# Altium schematic sheet Audio.SchDoc
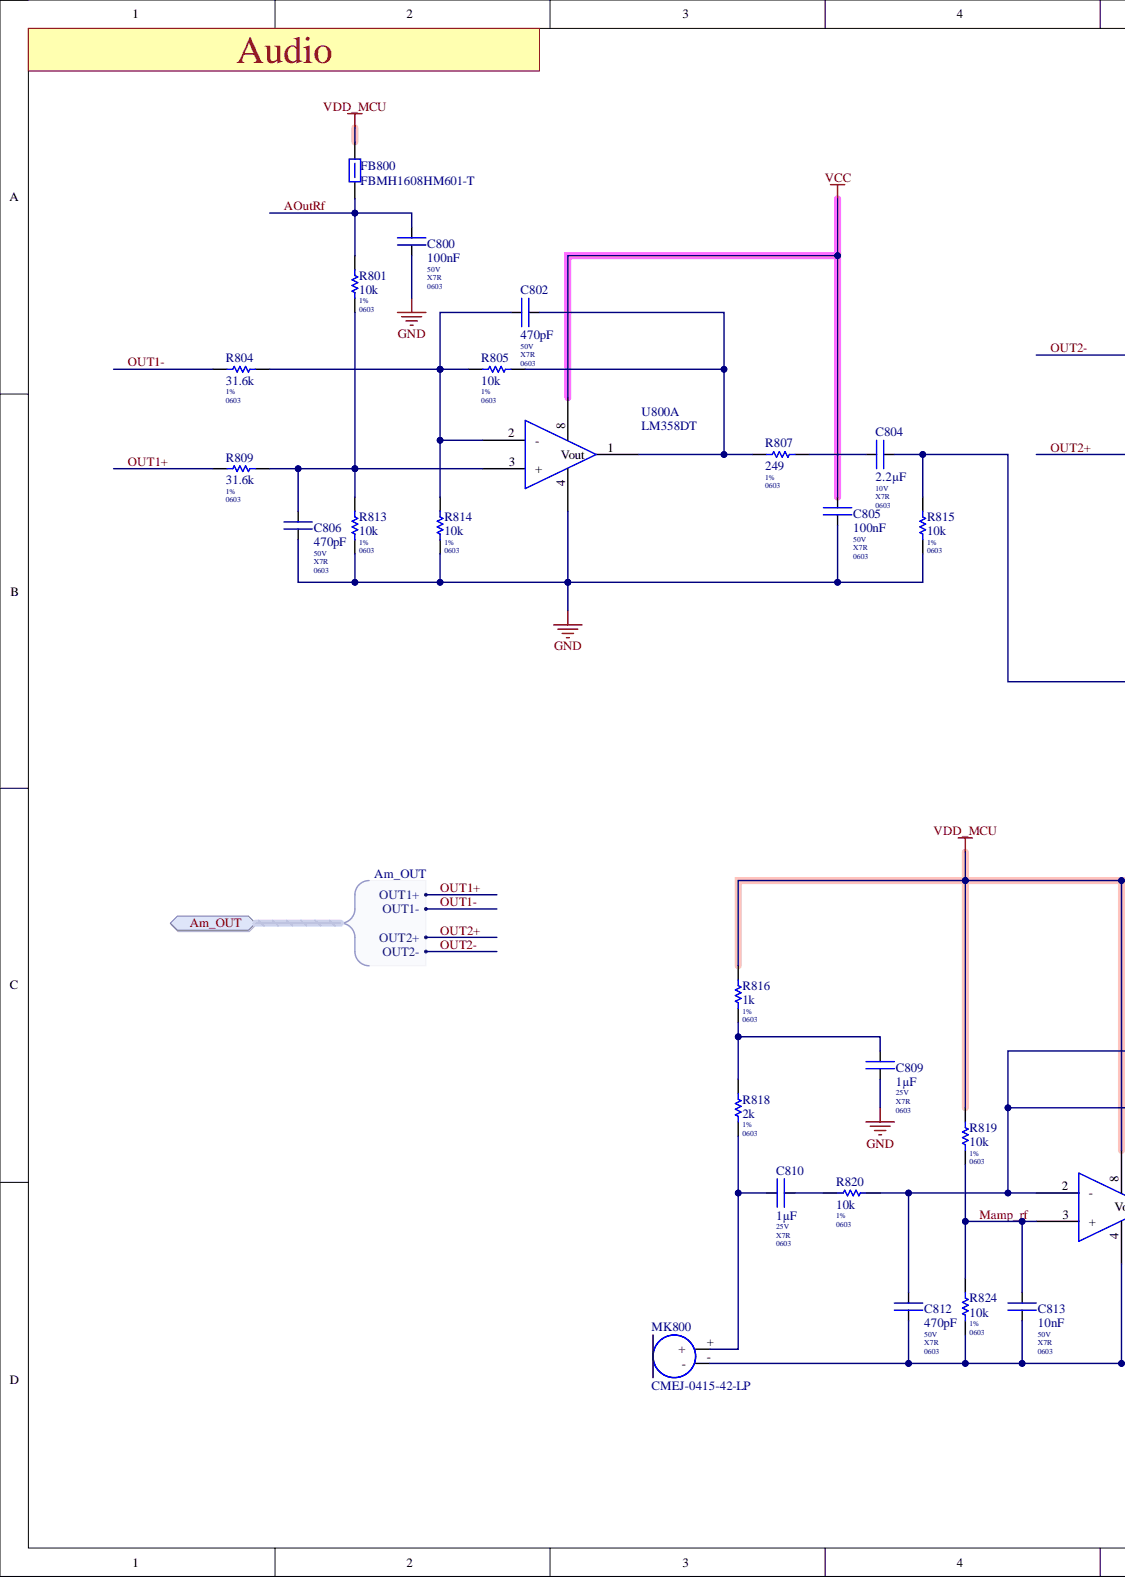
[diagram p0: Audio.SchDoc, left]
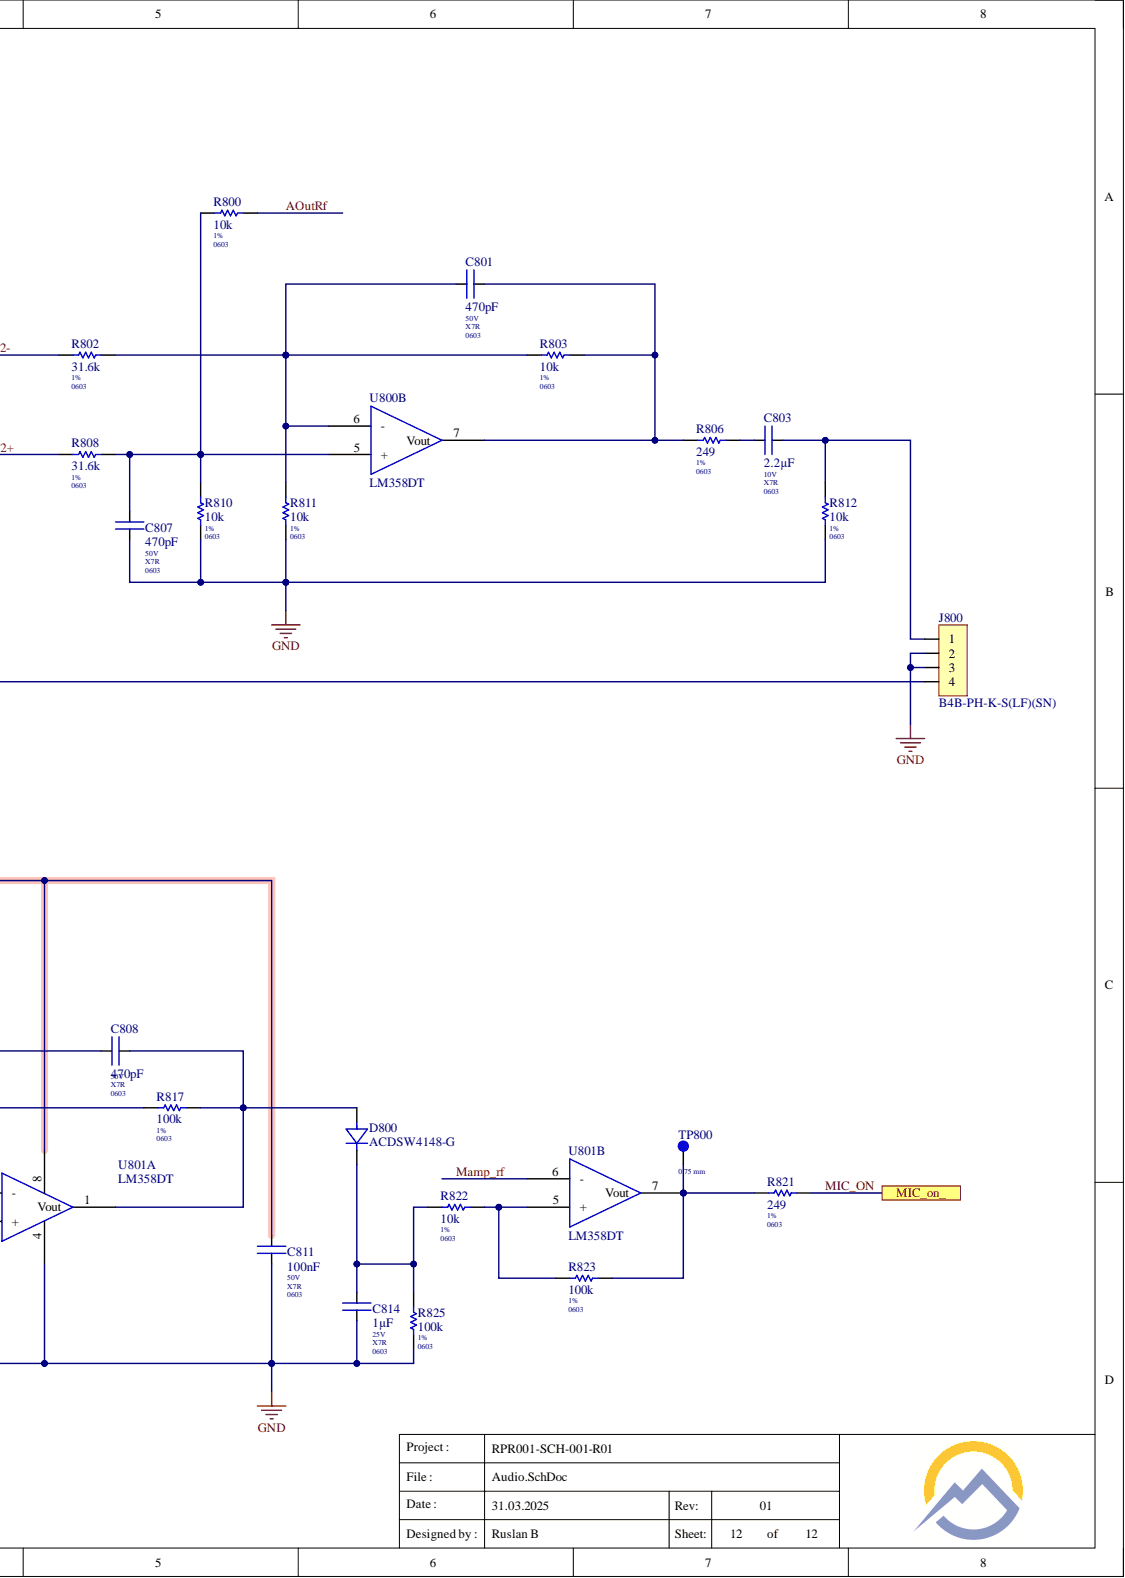
[diagram p1: Audio.SchDoc, right]

## Parts
C800  value 100nF  Case/Package: 0603  Dielectric: X7R  Voltage Rating: 50V  [B_Cap_0603_KEM]  (hidden comment: GCM188R71H104KA57D)
C801  value 470pF  Dielectric: X7R  Voltage Rating: 50V  [B_Cap_0603_KEM]  (hidden comment: VJ0603Y471KLAAJ32)
C802  value 470pF  Voltage Rating: 50V  [B_Cap_0603_KEM]  (hidden comment: VJ0603Y471KLAAJ32)
C803  value 2.2µF  Dielectric: X7R  Voltage Rating: 10V  [B_Cap_0603_KEM]  (hidden comment: CL10B225KP8NNNC)
C804  value 2.2µF  Voltage Rating: 10V  [B_Cap_0603_KEM]  (hidden comment: CL10B225KP8NNNC)
C805  value 100nF  Case/Package: 0603  Dielectric: X7R  Voltage Rating: 50V  [B_Cap_0603_KEM]  (hidden comment: GCM188R71H104KA57D)
C806  value 470pF  Case/Package: 0603  Dielectric: X7R  Voltage Rating: 50V  [B_Cap_0603_KEM]  (hidden comment: VJ0603Y471KLAAJ32)
C807  value 470pF  Case/Package: 0603  Dielectric: X7R  Voltage Rating: 50V  [B_Cap_0603_KEM]  (hidden comment: VJ0603Y471KLAAJ32)
C808  value 470pF  Dielectric: X7R  Voltage Rating: 50V  [B_Cap_0603_KEM]  (hidden comment: VJ0603Y471KLAAJ32)
C809  value 1µF  Case/Package: 0603  Dielectric: X7R  Voltage Rating: 25V  [B_Cap_0603_KEM]  (hidden comment: CL10B105KA8NNNC)
C810  value 1µF  Case/Package: 0603  Dielectric: X7R  Voltage Rating: 25V  [B_Cap_0603_KEM]  (hidden comment: CL10B105KA8NNNC)
C811  value 100nF  Case/Package: 0603  Dielectric: X7R  Voltage Rating: 50V  [B_Cap_0603_KEM]  (hidden comment: GCM188R71H104KA57D)
C812  value 470pF  Case/Package: 0603  Dielectric: X7R  Voltage Rating: 50V  [B_Cap_0603_KEM]  (hidden comment: VJ0603Y471KLAAJ32)
C813  value 10nF  Case/Package: 0603  Dielectric: X7R  Voltage Rating: 50V  [B_Cap_0603_KEM]  (hidden comment: CC0603MRX7R9BB103)
C814  value 1µF  Case/Package: 0603  Dielectric: X7R  Voltage Rating: 25V  [B_Cap_0603_KEM]  (hidden comment: CL10B105KA8NNNC)
D800  ACDSW4148-G  [SOD-123]
FB800  FBMH1608HM601-T  [FBMH1608HM601-T-Footprint-1]
J800  B4B-PH-K-S(LF)(SN)  [B4B-PH-K-S(LF)(SN)]
MK800  CMEJ-0415-42-LP  [PCBComponent]
R800  value 10k  Case/Package: 0603  Tolerance: 1%  [Res_0603_YAG]  (hidden comment: RC0603FR-0710KL)
R801  value 10k  Case/Package: 0603  Tolerance: 1%  [Res_0603_YAG]  (hidden comment: RC0603FR-0710KL)
R802  value 31.6k  Case/Package: 0603  Tolerance: 1%  [Res_0603_YAG]  (hidden comment: RMCF0603FT31K6)
R803  value 10k  Case/Package: 0603  Tolerance: 1%  [Res_0603_YAG]  (hidden comment: RC0603FR-0710KL)
R804  value 31.6k  Case/Package: 0603  Tolerance: 1%  [Res_0603_YAG]  (hidden comment: RMCF0603FT31K6)
R805  value 10k  Case/Package: 0603  [Res_0603_YAG]  (hidden comment: RC0603FR-0710KL)
R806  value 249  Case/Package: 0603  Tolerance: 1%  [Res_0603_YAG]  (hidden comment: RC0603FR-07249RL)
R807  value 249  Case/Package: 0603  Tolerance: 1%  [Res_0603_YAG]  (hidden comment: RC0603FR-07249RL)
R808  value 31.6k  Case/Package: 0603  Tolerance: 1%  [Res_0603_YAG]  (hidden comment: RMCF0603FT31K6)
R809  value 31.6k  Case/Package: 0603  Tolerance: 1%  [Res_0603_YAG]  (hidden comment: RMCF0603FT31K6)
R810  value 10k  Case/Package: 0603  Tolerance: 1%  [Res_0603_YAG]  (hidden comment: RC0603FR-0710KL)
R811  value 10k  Case/Package: 0603  Tolerance: 1%  [Res_0603_YAG]  (hidden comment: RC0603FR-0710KL)
R812  value 10k  Case/Package: 0603  Tolerance: 1%  [Res_0603_YAG]  (hidden comment: RC0603FR-0710KL)
R813  value 10k  Case/Package: 0603  Tolerance: 1%  [Res_0603_YAG]  (hidden comment: RC0603FR-0710KL)
R814  value 10k  Case/Package: 0603  Tolerance: 1%  [Res_0603_YAG]  (hidden comment: RC0603FR-0710KL)
R815  value 10k  Case/Package: 0603  Tolerance: 1%  [Res_0603_YAG]  (hidden comment: RC0603FR-0710KL)
R816  value 1k  Case/Package: 0603  Tolerance: 1%  [Res_0603_YAG]  (hidden comment: RC0603FR-071KL)
R817  value 100k  Case/Package: 0603  Tolerance: 1%  [Res_0603_YAG]  (hidden comment: RMCF0603FT100K)
R818  value 2k  Case/Package: 0603  Tolerance: 1%  [Res_0603_YAG]  (hidden comment: RC0603FR-072KL)
R819  value 10k  Case/Package: 0603  Tolerance: 1%  [Res_0603_YAG]  (hidden comment: RC0603FR-0710KL)
R820  value 10k  Case/Package: 0603  Tolerance: 1%  [Res_0603_YAG]  (hidden comment: RC0603FR-0710KL)
R821  value 249  Case/Package: 0603  Tolerance: 1%  [Res_0603_YAG]  (hidden comment: RC0603FR-07249RL)
R822  value 10k  Case/Package: 0603  Tolerance: 1%  [Res_0603_YAG]  (hidden comment: RC0603FR-0710KL)
R823  value 100k  Case/Package: 0603  Tolerance: 1%  [Res_0603_YAG]  (hidden comment: RMCF0603FT100K)
R824  value 10k  Case/Package: 0603  Tolerance: 1%  [Res_0603_YAG]  (hidden comment: RC0603FR-0710KL)
R825  value 100k  Case/Package: 0603  Tolerance: 1%  [Res_0603_YAG]  (hidden comment: RMCF0603FT100K)
TP800  0.75 mm  [0.75mm]  (no BOM)
U800  LM358DT  [8-SOIC]  (parts A,B)
U801  LM358DT  [8-SOIC]  (parts A,B)

## Ports
Am_OUT, Am_OUT.OUT1+, Am_OUT.OUT1-, Am_OUT.OUT2+, Am_OUT.OUT2-, MIC_on_

## Nets
GND: C800.1 C805.1 C806.2 C807.2 C809.1 C811.1 C812.1 C813.1 C814.1 J800.2 J800.3 MK800.- R810.1 R811.1 R812.2 R813.1 R814.1 R815.2 R824.2 R825.2 U800.4 U801.4
VCC: C805.2 U800.8
VDD_MCU: C811.2 FB800.2 R816.2 R819.1 U801.8
AOutRf: C800.2 FB800.1 R800.1 R801.1
Mamp_rf: C813.2 R819.2 R824.1 U801.3(+) U801.6(-)
MIC_ON: R821.2 ; port MIC_on_
OUT1+: R809.2 ; port Am_OUT
OUT1-: R804.2 ; port Am_OUT
OUT2+: R808.2 ; port Am_OUT
OUT2-: R802.2 ; port Am_OUT
NetC801_1: C801.1 R803.1 R806.1 U800.7(Vout)
NetC801_2: C801.2 R802.1 R803.2 R811.2 U800.6(-)
NetC802_1: C802.1 R805.1 R807.1 U800.1(Vout)
NetC802_2: C802.2 R804.1 R805.2 R814.2 U800.2(-)
NetC803_1: C803.1 J800.1 R812.1
NetC803_2: C803.2 R806.2
NetC804_1: C804.1 J800.4 R815.1
NetC804_2: C804.2 R807.2
NetC806_1: C806.1 R801.2 R809.1 R813.2 U800.3(+)
NetC807_1: C807.1 R800.2 R808.1 R810.2 U800.5(+)
NetC808_1: C808.1 C812.2 R817.2 R820.2 U801.2(-)
NetC808_2: C808.2 D800.2 R817.1 U801.1(Vout)
NetC809_2: C809.2 R816.1 R818.2
NetC810_1: C810.1 MK800.+ R818.1
NetC810_2: C810.2 R820.1
NetC814_2: C814.2 D800.1 R822.1 R825.1
NetR821_1: R821.1 R823.2 TP800.1 U801.7(Vout)
NetR822_2: R822.2 R823.1 U801.5(+)

## Library data of the parts
C800 C805 C811 = CMP-CAP-CER-00027-13: CAP CER 0.1UF 50V X7R 0603; Manufacturer 1: Murata; Tolerance: ±10%; Component Kind: Standard; ComponentLink1Description: Datasheet; ComponentLink1URL: https://search.murata.co.jp/Ceramy/image/img/A01X/G101/ENG/GCM188R71H104KA57-01.pdf; Library Name: <Company> Server; Manufacturer Part Number 1: GCM188R71H104KA57D
C801 C802 C806-C808 C812 = CMP-CAP-CER-00212-11: CAP CER 470 PF 50V X7R 0603 PB T; Manufacturer 1: Vishay; Tolerance: ±10%; Component Kind: Standard; ComponentLink1Description: Datasheet; ComponentLink1URL: https://www.vishay.com/docs/45200/vjhirelseries.pdf; Library Name: <Company> Server; Manufacturer Part Number 1: VJ0603Y471KLAAJ32
  C801 C808: Case/Package: 0603
  C802: Case/Package: 0603; Dielectric: X7R
C803 C804 = CMP-CAP-CER-00144-13: CAP CER 2.2UF 10V X7R 0603; Manufacturer 1: Samsung; Tolerance: ±10%; Case/Package: 0603; Component Kind: Standard; ComponentLink1Description: Datasheet; ComponentLink1URL: http://www.samsungsem.com/kr/support/product-search/mlcc/CL10B225KP8NNNC.jsp; Library Name: <Company> Server; Manufacturer Part Number 1: CL10B225KP8NNNC
  C804: Dielectric: X7R
C809 C810 C814 = CMP-CAP-CER-00132-13: CL10B105KA8NNNC Samsung Ceramic Capacitor MLCC 1uF10% 25V X7R SMD 0603 (1608 Metric) RoHS; Manufacturer 1: Samsung Electro-Mechanics; Tolerance: 10%; Component Kind: Standard; Library Name: <Company> Server; Manufacturer Part Number 1: CL10B105KA8NNNC
C813 = CMP-CAP-CER-00261-3: 0603 10 nF 50 V ±20% Tolerance X7R SMT Multilayer Ceramic Capacitor; Manufacturer 1: Yageo; Component Kind: Standard; Library Name: <Company> Server; Manufacturer Part Number 1: CC0603MRX7R9BB103; Tolerance: 20%
D800 = CMP-DIO-RECT-00005-8: DIODE GEN PURP 100V 150MA SOD123; Manufacturer 1: Comchip; Forward Voltage: 1.25V; Average Rectified Current: 150mA; Case/Package: SOD-123; Component Kind: Standard; ComponentLink1Description: Datasheet; ComponentLink1URL: https://www.comchiptech.com/admin/files/product/ACDSW4148-G%20RevA570218.pdf; Forward Current: 150mA; Library Name: <Company> Server; Manufacturer Part Number 1: ACDSW4148-G; Max Reverse Voltage (DC): 100V
FB800 = CMP-FIL-FB-00013-5: FERRITE BEAD 600 OHM 0603 1LN; Manufacturer 1: Taiyo Yuden; Current Rating: 700mA; Impedance: 600; Tolerance: 25%; Case/Package: 0603; Component Kind: Standard; ComponentLink1Description: Datasheet; ComponentLink1URL: https://ds.yuden.co.jp/TYCOMPAS/ut/detail?pn=FBMH1608HM601-T  &u=M; Library Name: <Company> Server; Manufacturer Part Number 1: FBMH1608HM601-T
J800 = CMP-CON-HEAD-00143-3: CONN HEADER VERT 4POS 2MM; Manufacturer 1: JST; Current Rating: 2A; Gender: Male; Number of Contacts: 4; Pitch: 2.00mm; Voltage Rating: 100V; Component Kind: Standard; ComponentLink1Description: Datasheet; ComponentLink1URL: http://www.jst-mfg.com/product/pdf/eng/ePH.pdf; Library Name: <Company> Server; Manufacturer Part Number 1: B4B-PH-K-S(LF)(SN); Number of Positions: 4; Number of Rows: 1
MK800 = CMP-AUD-MPH-00002-5: MICROPHONE, 4 MM, ELECTRET CONDE; Manufacturer 1: Same Sky; Direction: Omnidirectional; Output Type: Analog; Supply Current: 500µA; Voltage Rating: 2V; Component Kind: Standard; ComponentLink1Description: Datasheet; ComponentLink1URL: https://www.cuidevices.com/product/resource/digikeypdf/cmej-0415-42-lp.pdf; Library Name: <Company> Server; Manufacturer Part Number 1: CMEJ-0415-42-LP
R800 R801 R803 R805 R810-R815 R819 R820 R822 R824 = CMP-RES-SMD-00183-9: RES SMD 10K OHM 1% 1/10W 0603; Manufacturer 1: Yageo; Temperature Coefficient: ±100ppm/℃; Component Kind: Standard; ComponentLink1Description: Datasheet; ComponentLink1URL: https://www.yageo.com/upload/media/product/productsearch/datasheet/rchip/PYu-RC_Group_51_RoHS_L_10.pdf; Library Name: <Company> Server; Manufacturer Part Number 1: RC0603FR-0710KL
  R805: Tolerance: 1%
R802 R804 R808 R809 = CMP-RES-SMD-00091-11: RES 31.6K OHM 1% 1/10W 0603; Manufacturer 1: Stackpole Electronics; Temperature Coefficient: ±100ppm/℃; Component Kind: Standard; ComponentLink1Description: Datasheet; ComponentLink1URL: https://www.seielect.com/catalog/sei-rmcf_rmcp.pdf; Library Name: <Company> Server; Manufacturer Part Number 1: RMCF0603FT31K6
R806 R807 R821 = CMP-RES-SMD-00474-3: Res Thick Film 0603 249 Ohm 1% 0.1W(1/10W) ±100ppm/°C Pad SMD T/R; Manufacturer 1: Yageo; Power Rating: 100mW; Voltage Rating: 50V; Component Kind: Standard; Library Name: <Company> Server; Manufacturer Part Number 1: RC0603FR-07249RL; Temperature Coefficient: 100ppm/°C
R816 = CMP-RES-SMD-00223-9: RES SMD 1K OHM 1% 1/10W 0603; Manufacturer 1: Yageo; Temperature Coefficient: ±100ppm/℃; Component Kind: Standard; ComponentLink1Description: Datasheet; ComponentLink1URL: https://www.yageo.com/upload/media/product/productsearch/datasheet/rchip/PYu-RC_Group_51_RoHS_L_10.pdf; Library Name: <Company> Server; Manufacturer Part Number 1: RC0603FR-071KL
R817 R823 R825 = CMP-RES-SMD-00047-11: RES 100K OHM 1% 1/10W 0603; Manufacturer 1: Stackpole Electronics; Temperature Coefficient: ±100ppm/℃; Component Kind: Standard; ComponentLink1Description: Datasheet; ComponentLink1URL: https://www.seielect.com/catalog/sei-rmcf_rmcp.pdf; Library Name: <Company> Server; Manufacturer Part Number 1: RMCF0603FT100K
R818 = CMP-RES-SMD-00080-11: RES 2K OHM 1% 1/10W 0603; Manufacturer 1: Yageo; Temperature Coefficient: ±100ppm/℃; Component Kind: Standard; ComponentLink1Description: Datasheet; ComponentLink1URL: https://www.yageo.com/upload/media/product/productsearch/datasheet/rchip/PYu-RC_Group_51_RoHS_L_11.pdf; Library Name: <Company> Server; Manufacturer Part Number 1: RC0603FR-072KL
TP800 = CMP-MF-TP-00003-4: Test point 0.75 mm; Library Name: <Company> Server; Size: 0.75 mm
U800 U801 = CMP-IC-AMP-00012-2: IC OPAMP GP 1.1MHZ 8SO; Manufacturer 1: STMicroelectronics; Amplifier Type: GENERAL PURPOSE; Common Mode Rejection Ratio: 70dB; Input Bias Current: 150nA; Input Offset Voltage (Vos): 7mV; Max Supply Voltage: 30V; Min Supply Voltage: 3V; Number of Elements: 2; Supply Current: 1.2mA; Supply Voltage: 15V; Voltage Gain: 100dB; Bandwidth: 1.1MHz; Case/Package: SOIC; Component Kind: Standard; Library Name: <Company> Server; Manufacturer Part Number 1: LM358DT
